# Altium schematic sheet mpc56_clk.SchDoc(mpc56_clk)
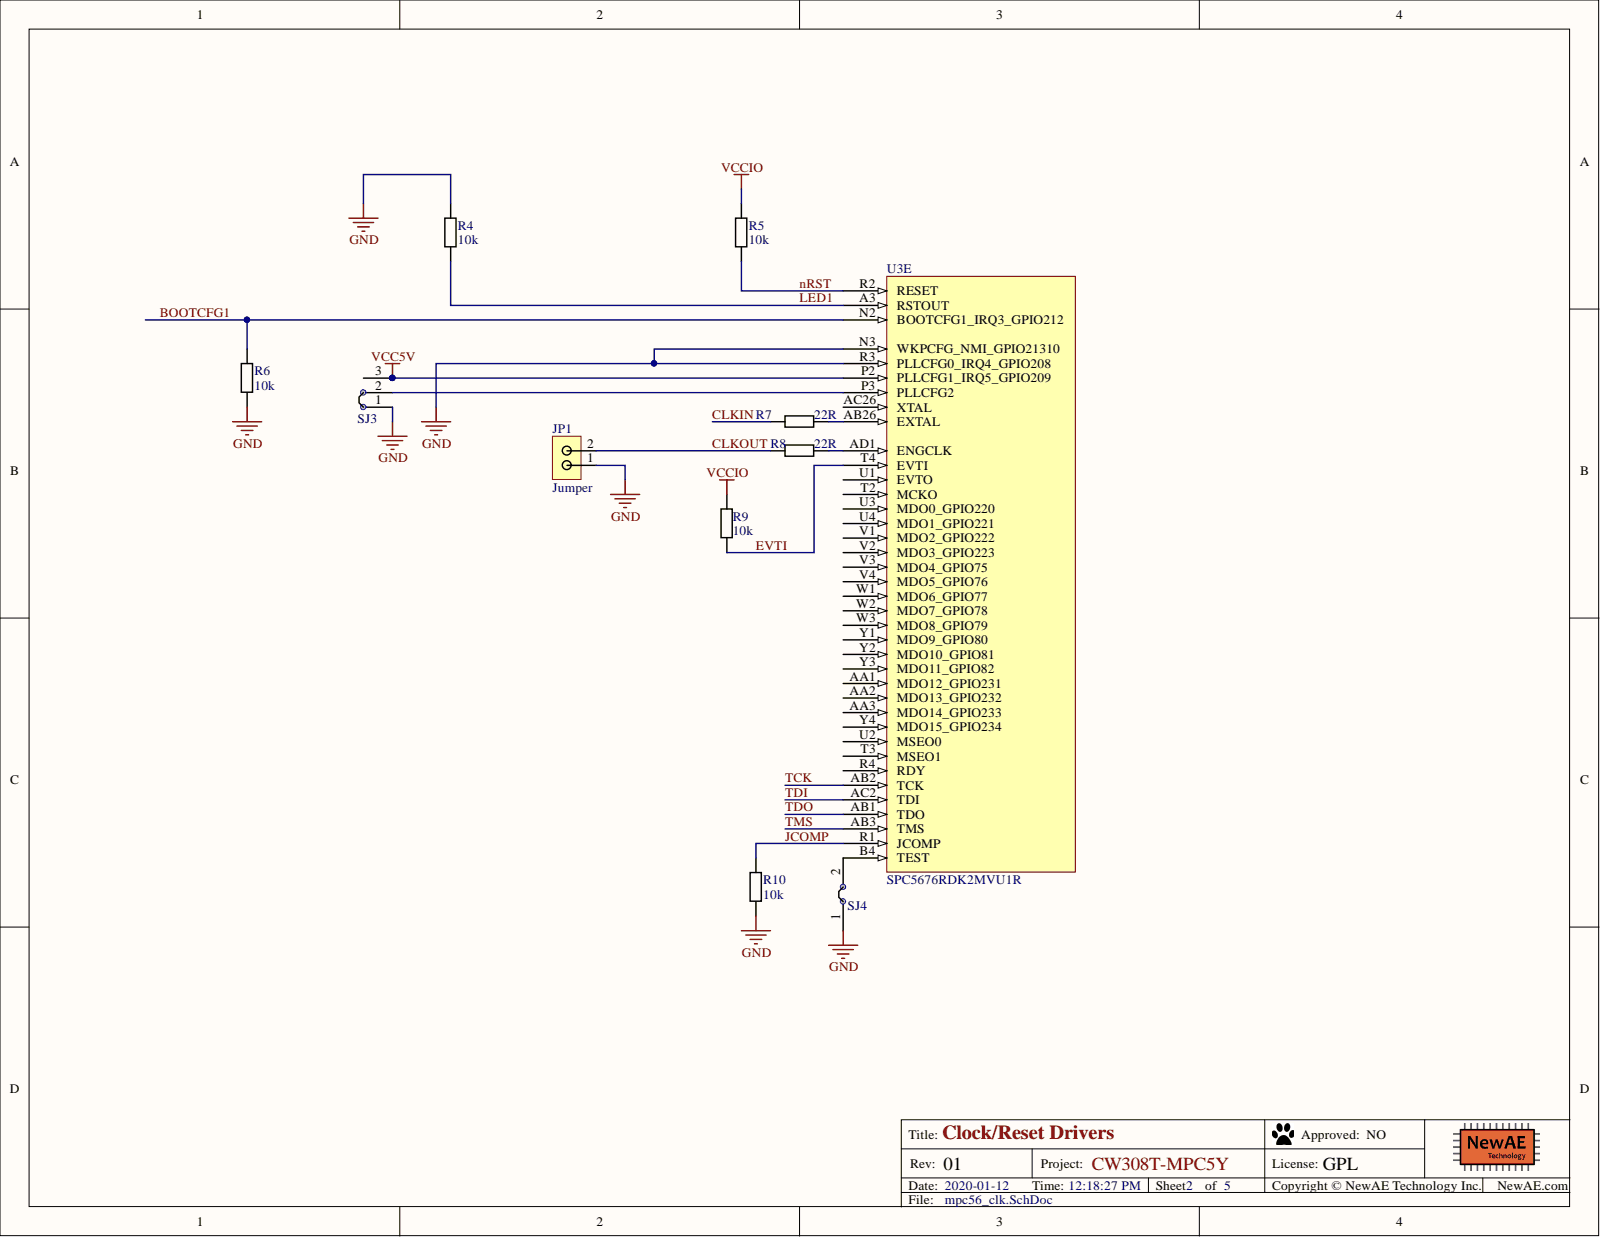
[diagram p0: mpc56_clk.SchDoc]

## Parts
JP1  Jumper  [2x2.54mm_Header]
R4  10k  [0603]
R5  10k  [0603]
R6  10k  [0603]
R7  22R  [0603]
R8  22R  [0603]
R9  10k  [0603]
R10  10k  [0603]
SJ3  NC  [SJ_3S_NC12]  (value not drawn)  (no BOM)
SJ4  NC  [SJ_2S_NC]  (value not drawn)  (no BOM)
U3  SPC5676RDK2MVU1R  [TEPBGA416_27x27]  (part E)

## Nets
GND: JP1.1 R4.1 R6.2 R10.2 SJ3.1 SJ4.1 U3.N3(WKPCFG_NMI_GPIO21310) U3.R3(PLLCFG0_IRQ4_GPIO208)
VCC5V: SJ3.3 U3.P2(PLLCFG1_IRQ5_GPIO209)
VCCIO: R5.1 R9.2
BOOTCFG1: R6.1 U3.N2(BOOTCFG1_IRQ3_GPIO212)
CLKIN: R7.2
CLKOUT: JP1.2 R8.2
EVTI: R9.1 U3.T4(EVTI)
JCOMP: R10.1 U3.R1(JCOMP)
LED1: R4.2 U3.A3(RSTOUT)
nRST: R5.2 U3.R2(RESET)
TCK: U3.AB2(TCK)
TDI: U3.AC2(TDI)
TDO: U3.AB1(TDO)
TMS: U3.AB3(TMS)
NetR7_1: R7.1 U3.AB26(EXTAL)
NetR8_1: R8.1 U3.AD1(ENGCLK)
NetSJ3_2: SJ3.2 U3.P3(PLLCFG2)
NetSJ4_2: SJ4.2 U3.B4(TEST)

Not connected: U3 AA1(MDO12_GPIO231) AA2(MDO13_GPIO232) AA3(MDO14_GPIO233) AC26(XTAL) R4(RDY) T2(MCKO) T3(MSEO1) U1(EVTO) U2(MSEO0) U3(MDO0_GPIO220) U4(MDO1_GPIO221) V1(MDO2_GPIO222) V2(MDO3_GPIO223) V3(MDO4_GPIO75) V4(MDO5_GPIO76) W1(MDO6_GPIO77) W2(MDO7_GPIO78) W3(MDO8_GPIO79) Y1(MDO9_GPIO80) Y2(MDO10_GPIO81) Y3(MDO11_GPIO82) Y4(MDO15_GPIO234)

## Library data of the parts
JP1 = 2_PIN_JUMPER: 1x2 2.54mm header, male pins; Manufacture Part Number 1: M20-9990246; Manufacture 1: Harwin; PP Rotation: 0; Database Table Name: main; Component Group: PCB Feature; Supplier Part Number 1: 952-2262-ND; Supplier 1: Digi-Key; RoHS: Yes; PP Material Stack: 0; Pin Count: 2; Part Number: 2_PIN_JUMPER; Mounting Technology: Through-hole; Library Name: NewAE_AltiumLib.DBLib; Component Type: Standard; Component Kind: Standard
R4-R6 R9 R10 = RCHIP-10K-0603: 10k res, 0603, 1%, 1/10W; Manufacture Part Number 1: RC0603FR-0710KL; Manufacture 1: Yageo; Rated Voltage: 75VDC; Rated Power: 0.1W; Database Table Name: main; Case-Metric: 1608; Value: 10k; Tolerance: 1%; Temperature Range: -55C to 155C; Supplier Part Number 1: 311-10.0KHRCT-ND; Supplier 1: Digi-Key; Pin Count: 2; Part Number: RCHIP-10K-0603; Mounting Technology: SMT; Library Name: NewAE_AltiumLib.DBLib; Component Type: Standard; Component Kind: Standard; Case-EIA: 0603
R7 R8 = RCHIP-22R-0603: 22R res, 0603, 1%, 1/10W; Manufacture Part Number 1: RC0603FR-0722RL; Manufacture 1: Yageo; Rated Voltage: 75VDC; Rated Power: 0.1W; Database Table Name: main; Case-Metric: 1608; Value: 22R; Tolerance: 1%; Temperature Range: -55C to 155C; Supplier Part Number 1: 311-22.0HRCT-ND; Supplier 1: Digi-Key; Pin Count: 2; Part Number: RCHIP-22R-0603; Mounting Technology: SMT; Library Name: NewAE_AltiumLib.DBLib; Component Type: Standard; Component Kind: Standard; Case-EIA: 0603
SJ3 = SJ_3S_12JMP: Solder Jumper, NC; Database Table Name: main; Component Group: PCB Feature; Pin Count: 3; Part Number: SJ_3S_12JMP; Mounting Technology: COPPER; Library Name: NewAE_AltiumLib.DBLib; Component Type: Standard (No BOM)
SJ4 = SJ_2S: Solder Jumper, NC; Database Table Name: main; Pin Count: 2; Part Number: SJ_2S; Library Name: NewAE_AltiumLib.DBLib; Component Type: Standard (No BOM)
U3 = SPC5676RDK2MVU1R: Dual-Core PPC, Automotive; Manufacture 1: NXP; PP Rotation: 0; Database Table Name: main; Component Group: Digital IC; Supplier 1: Mouser; RoHS: Yes; PP Material Stack: 0; Pin Count: 412; Part Number: SPC5676RDK2MVU1R; Mounting Technology: SMT; Manufacture Part Number 1: SPC5676RDK2MVU1R; Library Name: NewAE_AltiumLib.DBLib; Component Type: Standard; Component Kind: Standard
